# Altium schematic sheet MCU.SchDoc (continued)
## Library data of the parts (continued)
X1 = XRCGB40M000F1S1BR0: Crystal 40MHz ±10ppm (Tol) ±10ppm (Stability) 8pF 50Ohm 4-Pin CSMD T/R; Manufacturer: Murata; ESR: 50Ω; Frequency Tolerance: 0.001%; Category: Crystals; Component Kind: Standard; ComponentLink1Description: https://datasheet.octopart.com:443/XRCGB40M000F1S1BR0-Murata-datasheet-180814757.pdf?src-supplier=IHS; ComponentLink1URL: https://datasheet.octopart.com:443/XRCGB40M000F1S1BR0-Murata-datasheet-180814757.pdf?src-supplier=IHS; ComponentLink2Description: https://datasheet.octopart.com:443/XRCGB40M000F1S1BR0-Murata-datasheet-141295020.pdf?src-supplier=Ciiva; ComponentLink2URL: https://datasheet.octopart.com:443/XRCGB40M000F1S1BR0-Murata-datasheet-141295020.pdf?src-supplier=Ciiva; ComponentLink3Description: http://sigma.octopart.com:80/reach_statement/Murata-company-16.pdf?src-supplier=Compliance+Statements; ComponentLink3URL: http://sigma.octopart.com:80/reach_statement/Murata-company-16.pdf?src-supplier=Compliance+Statements; ESR (Equivalent Series Resistance): 50Ω; Export Control Classification Number (ECCN) Code: EAR99; Frequency: 40MHz; Frequency Stability: 10ppm; Harmonized Tariff Schedule (HTS) Code: 8541.60.00.60; Height: 700µm; Introduction Date: 2022-06-14; LCSC Part: C1870451; Library Name: Schlib.SchLib; Lifecycle Status: Production; Load Capacitance: 8pF; Manufacturer Part Number: XRCGB40M000F1S1BR0; Max Operating Temperature: 85°C; Min Operating Temperature: -30°C; Mounting Technology: Surface Mount; Pricing: 1=0,32, 10=0,275, 25=0,2596, 50=0,2488, 100=0,2386, 250=0,22564, 500=0,21632, 1000=0,20736 (USD); REACH SVHC: Yes; RoHS: Compliant; Schedule B: 8541600080, 8541600080|8541600080, 8541600080|8541600080|8541600080, 8541600080|8541600080|8541600080|8541600080; Stock: 1134; Supplier: DigiKey; Supplier Part Number: 490-XRCGB40M000F1S1BR0CT-ND; Tolerance: 0.001%
